# Altium schematic sheet ESP8266-12E.SchDoc
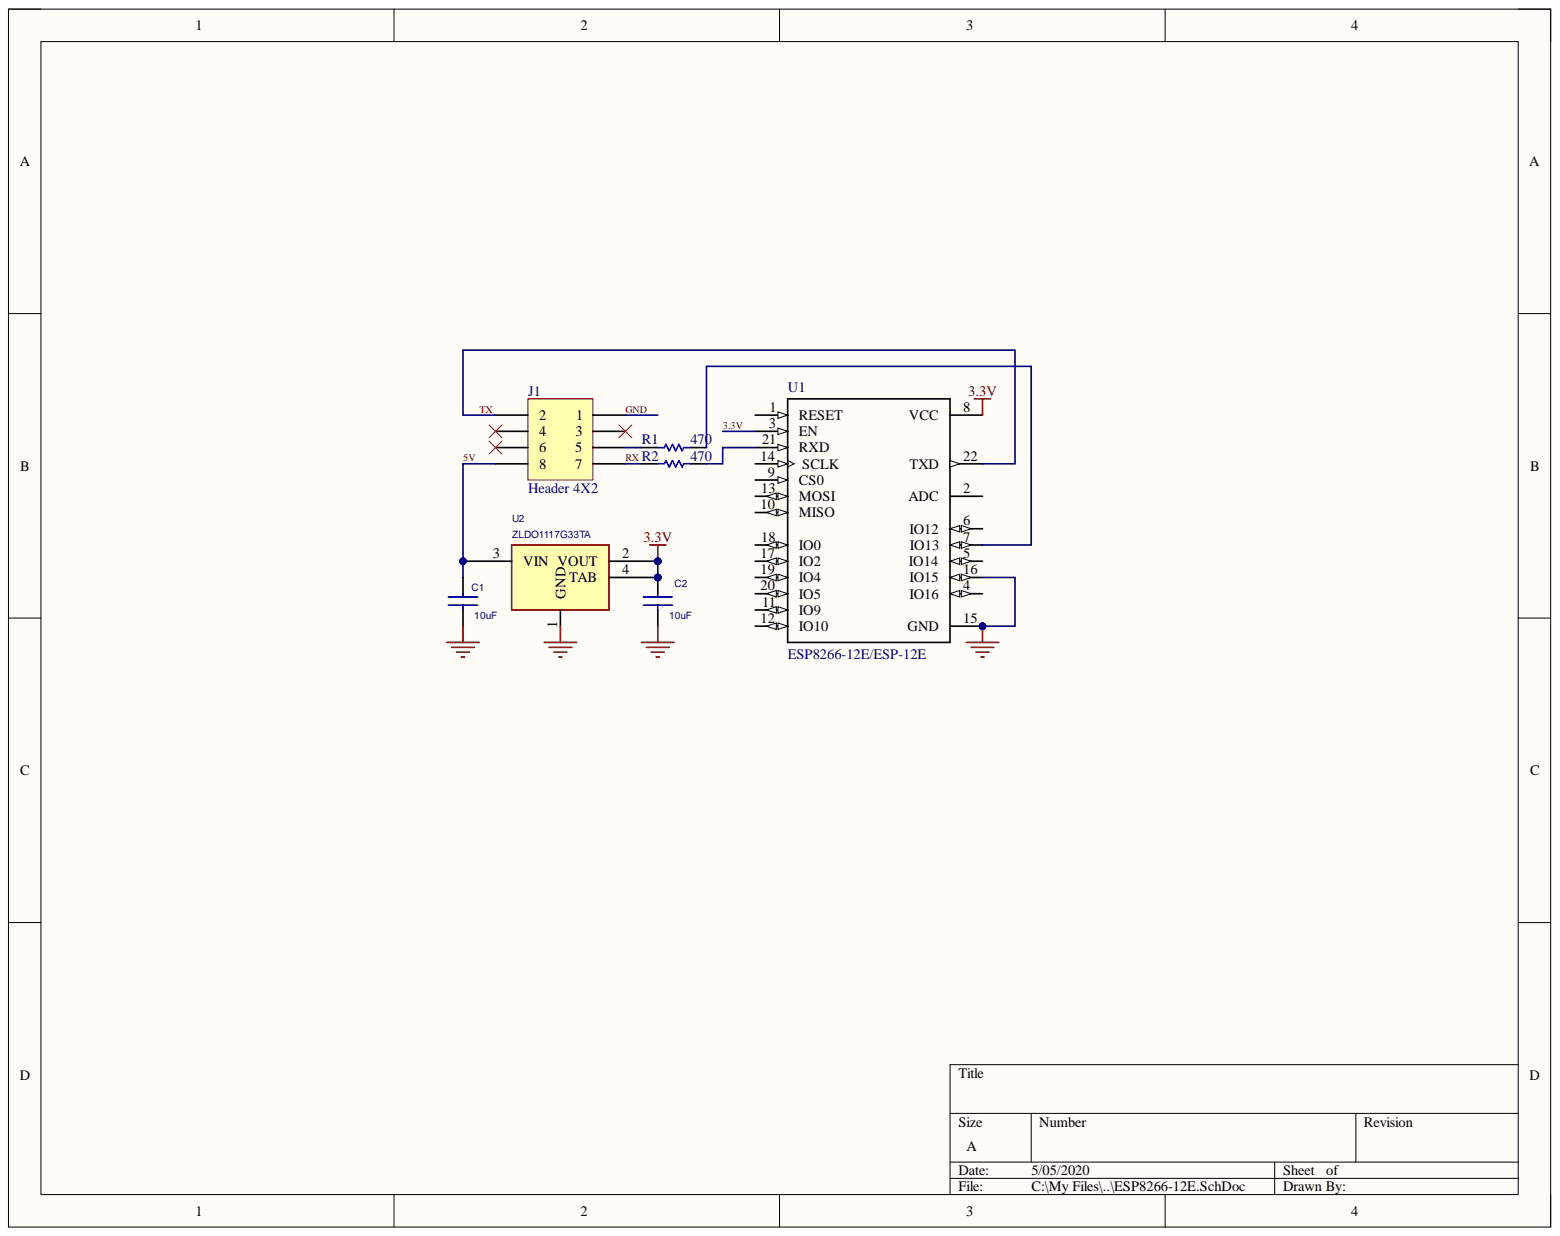
[diagram p0: ESP8266-12E.SchDoc]

## Parts
C1  10uF  [CAPC2012M]
C2  10uF  [CAPC2012M]
J1  Header 4X2  [HDR2X4]
R1  470  [SM/R_0805]
R2  470  [SM/R_0805]
U1  ESP8266-12E/ESP-12E  [*XCVR_ESP8266-12E/ESP-12E]
U2  ZLDO1117G33TA  [SM/SOT223]

## Nets
3.3V: C2.1 U1.3(EN) U1.8(VCC) U2.2(VOUT) U2.4(TAB)
5V: C1.1 J1.8 U2.3(VIN)
GND: C1.2 C2.2 J1.1 U1.15(GND) U1.16(IO15) U2.1(GND)
RX: J1.7 R2.2
TX: J1.2 U1.22(TXD)
NetJ1_5: J1.5 R1.2
NetR1_1: R1.1 U1.7(IO13)
NetR2_1: R2.1 U1.21(RXD)

Not connected: J1 3 4 6; U1 1(RESET) 2(ADC) 4(IO16) 5(IO14) 6(IO12) 9(CS0) 10(MISO) 11(IO9) 12(IO10) 13(MOSI) 14(SCLK) 17(IO2) 18(IO0) 19(IO4) 20(IO5)

## Library data of the parts
C1 = CAP NP: COMPFIXED: NO; COMPGROUP: 0; COMPHEIGHT: 0.000; COMPLOC: [340.000,620.000]; COMPSIDE: TOP; FPLIST: SM/C_0805_VIA,SM/C_0805_VIA1,SM/C_0603,SM/C_0805; COMPKEY: NO; COMPLOCKED: NO; Component Kind: Standard; COMPROT: 0; DECOUPLER: NO; MEMORY: NO
C2 = CAP NP: COMPFIXED: NO; COMPGROUP: 0; COMPHEIGHT: 0.000; COMPLOC: [250.000,620.000]; COMPROT: 180; COMPSIDE: TOP; FPLIST: SM/C_0805,SM/C_0603_VIA,SM/C_0603,SM/C_0805_VIA; COMPKEY: NO; COMPLOCKED: NO; Component Kind: Standard; DECOUPLER: NO; MEMORY: NO
J1 = Header 4X2: Header, 4-Pin, Dual row; Component Kind: Standard; LatestRevisionDate: 17-Jul-2002; LatestRevisionNote: Re-released for DXP Platform.; Library Name: Miscellaneous Connectors.IntLib; Signal Integrity: Connector; Publisher: Altium Limited
R1 R2 = Res1: Resistor; Component Kind: Standard; LatestRevisionDate: 17-Jul-2002; LatestRevisionNote: Re-released for DXP Platform.; Library Name: Miscellaneous Devices.IntLib; PackageReference: AXIAL-0.3; Published: 8-Jun-2000; Signal Integrity: Res; Simulation: RESISTOR; Value: 470; Publisher: Altium Limited
U1 = ESP8266-12E: This WiFi module has a 32-bit MCU micro and clock speeds supporting 80 MHz or 160 MHz. Supports the RTOS and integrated Wi-Fi MAC/BB/RF/PA/LNA, and has an on-board antenna.; MF: AI-Thinker; Availability: Unavailable; Component Kind: Standard; MP: ESP8266-12E/ESP-12E; Package: None; Price: None; SnapEDA_Link: https://www.snapeda.com/parts/ESP8266-12E/ESP-12E/AI-Thinker/view-part/?ref=snap; Library Name: Retro Computer.SchLib
U2 = REGULATOR-1: COMPFIXED: NO; COMPGROUP: 0; COMPHEIGHT: 0.000; COMPLOC: [1665.000,1750.000]; COMPSIDE: BOT; COMPKEY: NO; COMPLOCKED: NO; Component Kind: Standard; COMPROT: 0; DECOUPLER: NO; Library Name: ZX_UNO_B.SchLib; MEMORY: NO
